# Altium schematic sheet MCU.SchDoc(MCU)
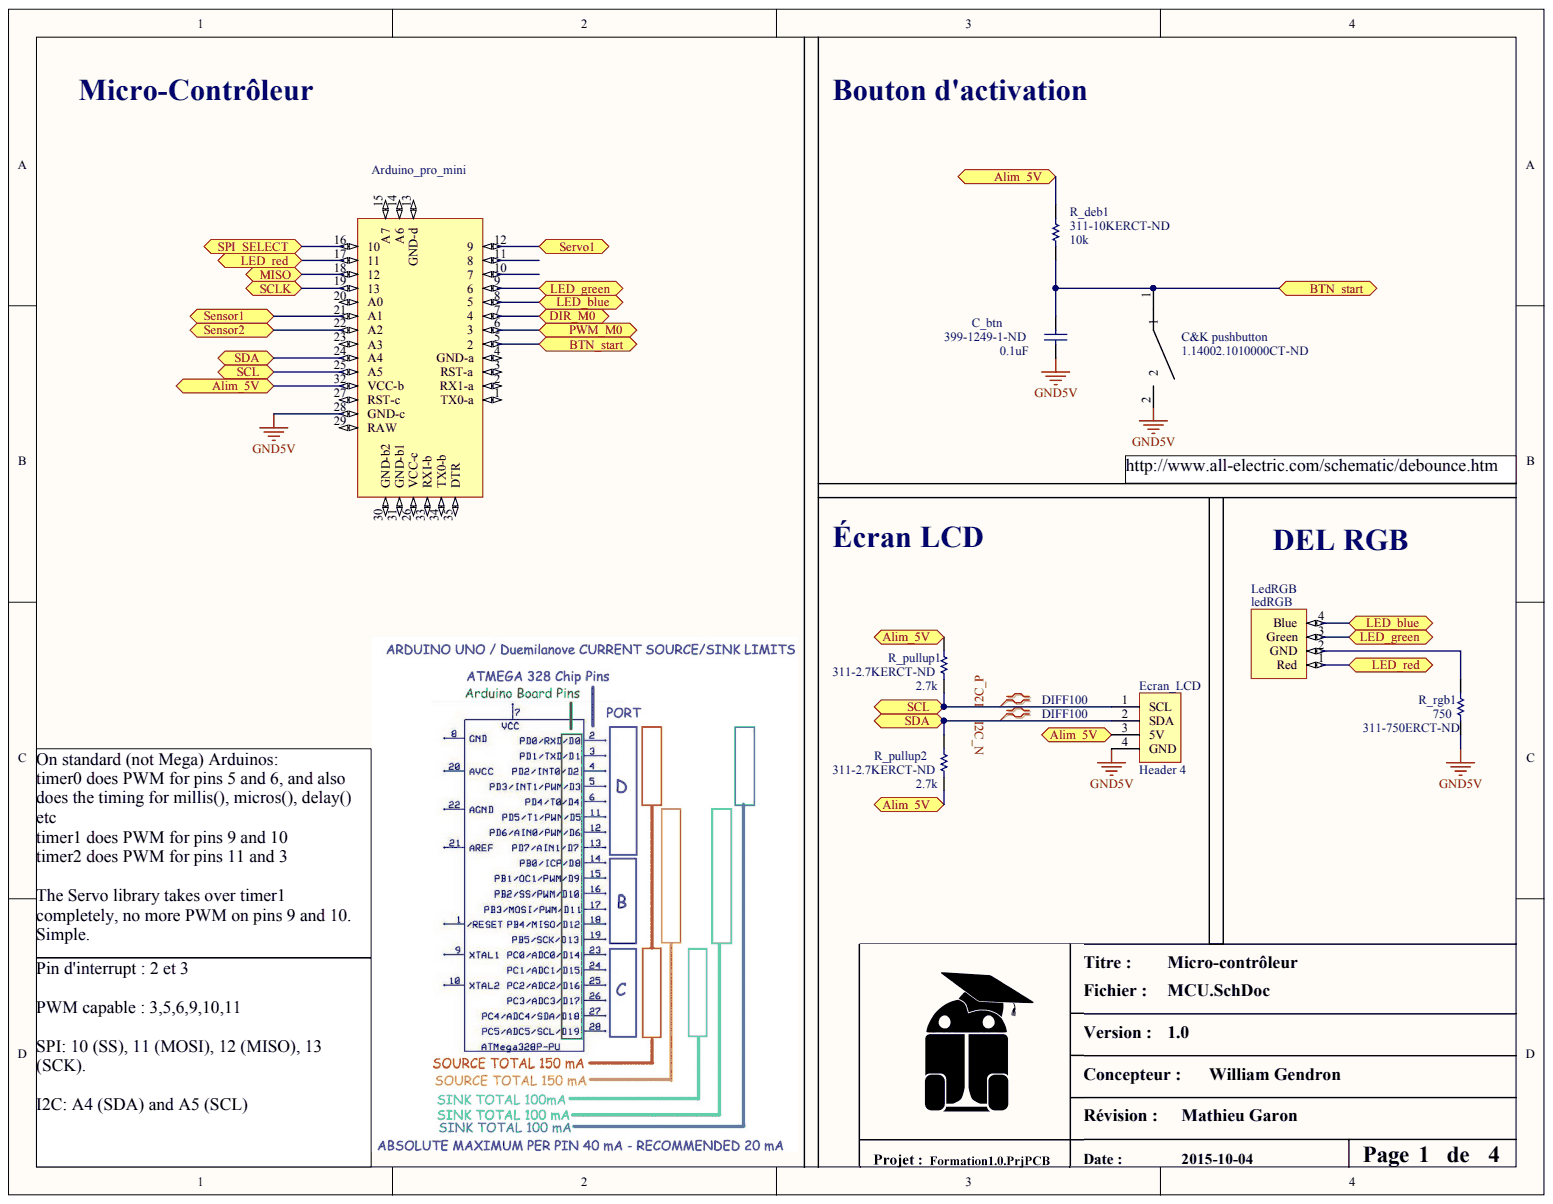
[diagram p0: MCU.SchDoc]

## Parts
Arduino_pro_mini  Arduino  [Arduino_pro_mini]
C&K_pushbutton  pushbutton - 1.14002.1010000CT-ND  Supplier Part Number 1: 1.14002.1010000CT-ND  [rafi1.14]
C_btn  value 0.1uF  Supplier Part Number 1: 399-1249-1-ND  [c1206]  (hidden comment: 0.1uf - 399-1249-1-ND)
Ecran_LCD  Header 4  [4PIN_254]
LedRGB  ledRGB  [LedRGB_3d]
R_deb1  value 10k  Supplier part number 1: 311-10KERCT-ND  [8-1206]  (hidden comment: 4.7Kohms - 311-4.7KERCT-ND)
R_pullup1  value 2.7k  Supplier part number 1: 311-2.7KERCT-ND  [8-1206]  (hidden comment: 4.7Kohms - 311-4.7KERCT-ND)
R_pullup2  value 2.7k  Supplier part number 1: 311-2.7KERCT-ND  [8-1206]  (hidden comment: 4.7Kohms - 311-4.7KERCT-ND)
R_rgb1  value 750  Supplier part number 1: 311-750ERCT-ND  [C1206]  (hidden comment: 220)

## Ports
ALIM_5V, BTN_START, DIR_M0, LED_BLUE, LED_GREEN, LED_RED, MISO, PWM_M0, SCL, SCLK, SDA, SENSOR1, SENSOR2, SERVO1, SPI_SELECT

## Nets
GND5V: Arduino_pro_mini.28(GND-c) C&K_pushbutton.2 C_btn.1 Ecran_LCD.4(GND) R_rgb1.1
DIR_M0: Arduino_pro_mini.7 ; port DIR_M0
I2C_N: Arduino_pro_mini.24(A4) Ecran_LCD.2(SDA) R_pullup2.2 ; port SDA
I2C_P: Arduino_pro_mini.25(A5) Ecran_LCD.1(SCL) R_pullup1.1 ; port SCL
MISO: Arduino_pro_mini.18 ; port MISO
PWM_M0: Arduino_pro_mini.6 ; port PWM_M0
SCLK: Arduino_pro_mini.19 ; port SCLK
SPI_SELECT: Arduino_pro_mini.16 ; port SPI_SELECT
NetArduino_pro_mini_5: Arduino_pro_mini.5 C&K_pushbutton.1 C_btn.2 R_deb1.1 ; port BTN_START
NetArduino_pro_mini_8: Arduino_pro_mini.8 LedRGB.4(Blue) ; port LED_BLUE
NetArduino_pro_mini_9: Arduino_pro_mini.9 LedRGB.3(Green) ; port LED_GREEN
NetArduino_pro_mini_12: Arduino_pro_mini.12 ; port SERVO1
NetArduino_pro_mini_17: Arduino_pro_mini.17 LedRGB.1(Red) ; port LED_RED
NetArduino_pro_mini_21: Arduino_pro_mini.21(A1) ; port SENSOR1
NetArduino_pro_mini_22: Arduino_pro_mini.22(A2) ; port SENSOR2
NetArduino_pro_mini_32: Arduino_pro_mini.32(VCC-b) Ecran_LCD.3(5V) R_deb1.2 R_pullup1.2 R_pullup2.1 ; port ALIM_5V
NetLedRGB_2: LedRGB.2(GND) R_rgb1.2

Not connected: Arduino_pro_mini 1(TX0-a) 2(RX1-a) 3(RST-a) 4(GND-a) 10 11 13(GND-d) 14(A6) 15(A7) 20(A0) 23(A3) 26(VCC-c) 27(RST-c) 29(RAW) 30(GND-b2) 31(GND-b1) 33(RXI-b) 34(TX0-b) 35(DTR)

## Library data of the parts
C&K_pushbutton = pushbutton: Component Kind: Standard; Library Name: Sim808.SchLib; Supplier 1: Digi-Key
C_btn = Cap: Capacitor; Component Kind: Standard; Library Name: Miscellaneous Devices.IntLib; Signal Integrity: Cap; Simulation: CAP; Supplier 1: Digi-Key
Ecran_LCD = Header 4: Header, 4-Pin; Complementary Part 1: A31082-ND; Component Kind: Standard; Library Name: Miscellaneous Connectors.IntLib; Signal Integrity: Connector; Supplier 1: Digi-Key; Supplier Part Number 1: A19431-ND
R_deb1 R_pullup1 R_pullup2 R_rgb1 = Res1: Resistor; Component Kind: Standard; Library Name: Miscellaneous Devices.IntLib; Signal Integrity: Res; Simulation: RESISTOR; Supplier 1: Digi-Key
Arduino_pro_mini = Arduino: Component Kind: Standard; Library Name: Arduino.SchLib
LedRGB = ledRGB: Component Kind: Standard; Library Name: LedRGB.SchLib
